# Altium schematic sheet USB UART.SchDoc("USB UART")
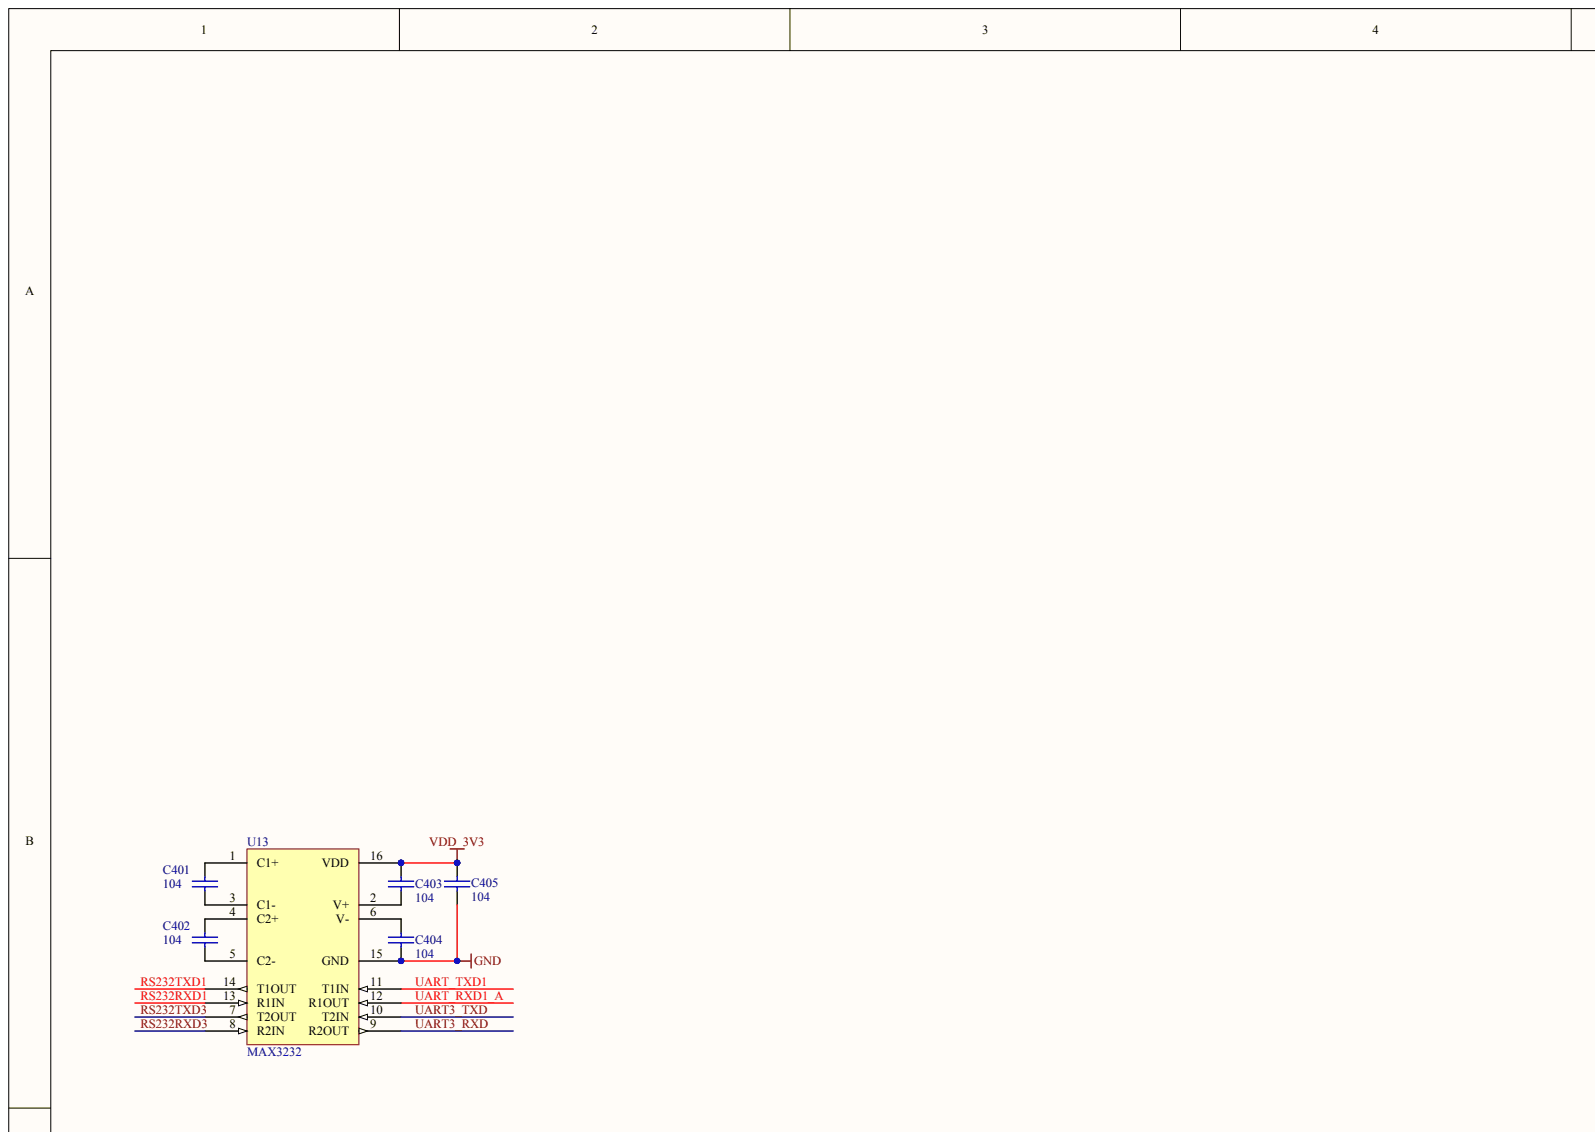
[diagram p0: USB UART.SchDoc, top left]
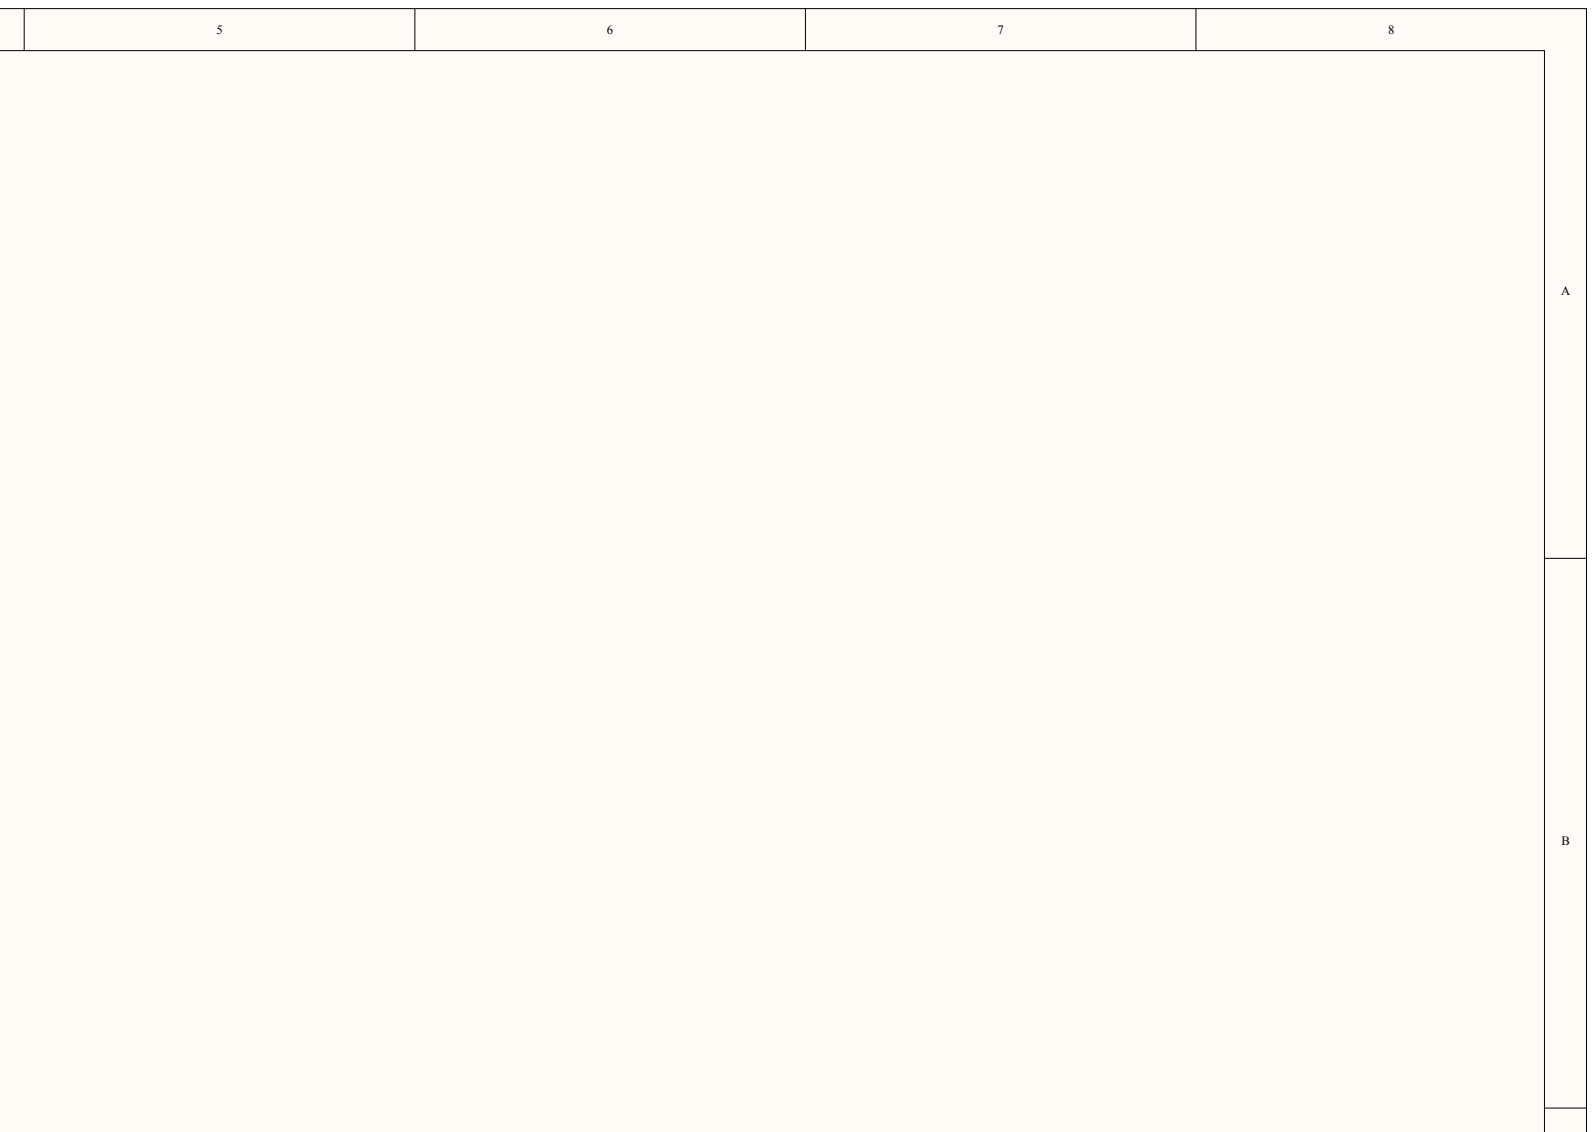
[diagram p1: USB UART.SchDoc, top right]
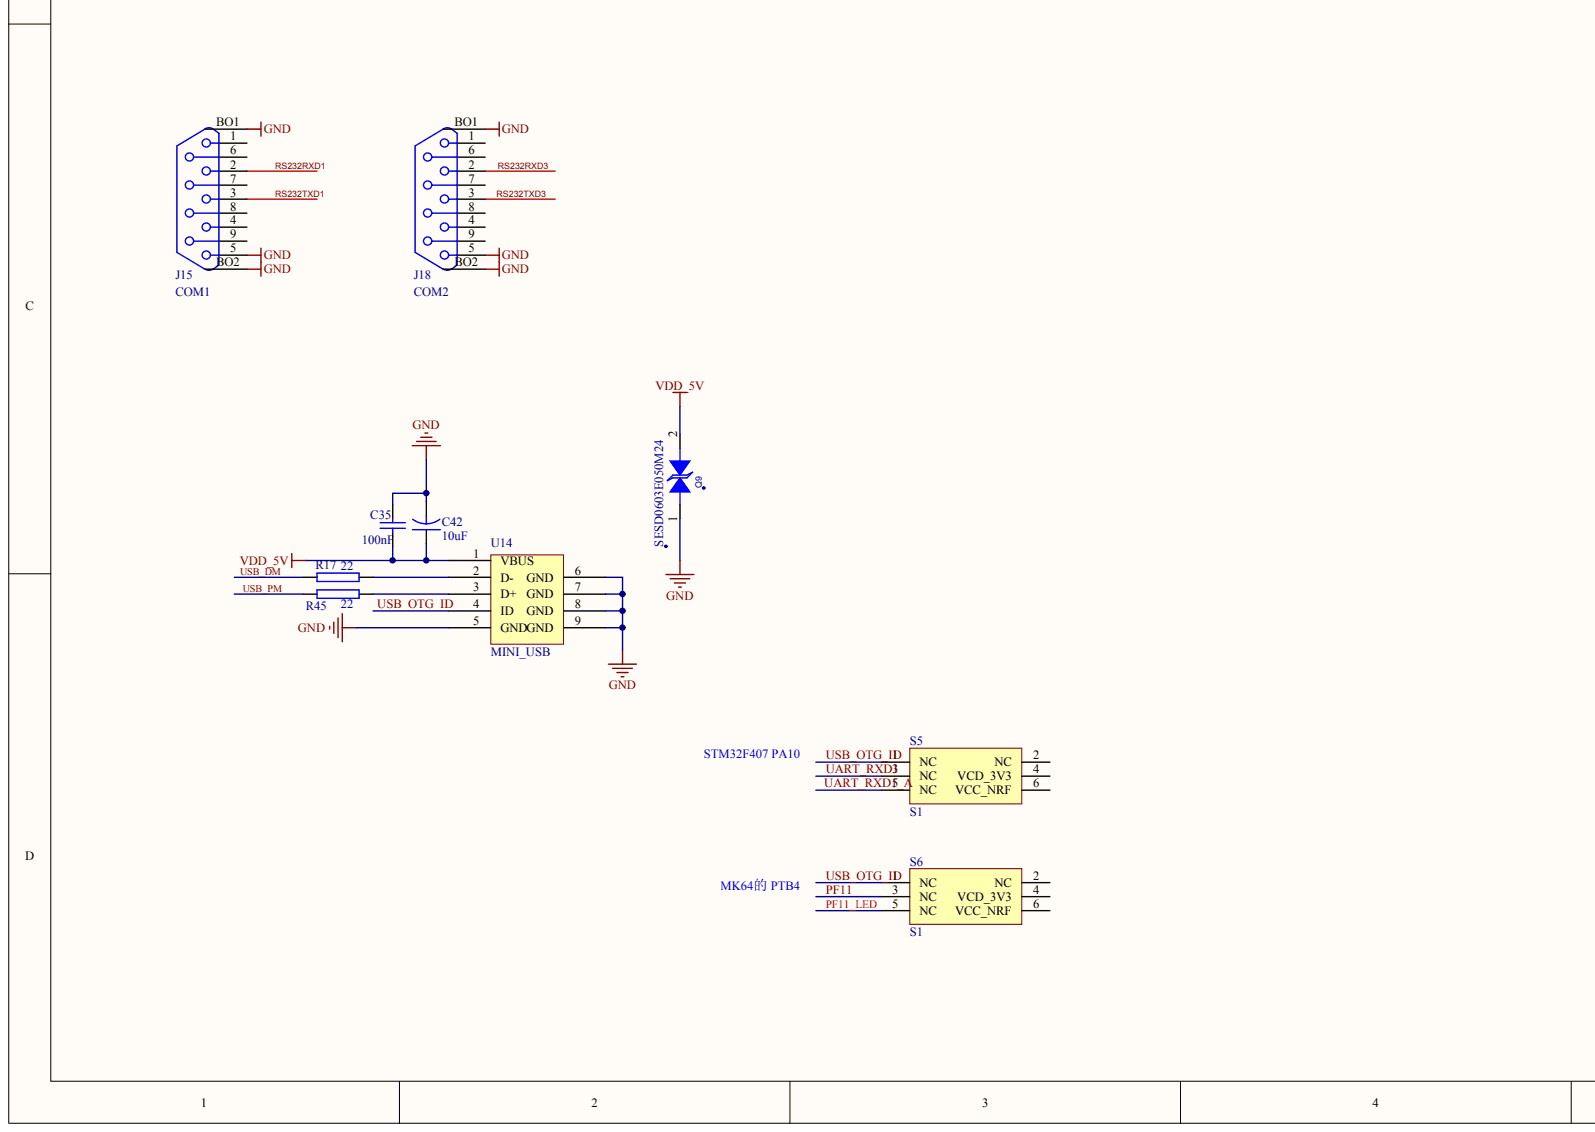
[diagram p2: USB UART.SchDoc, bottom left]
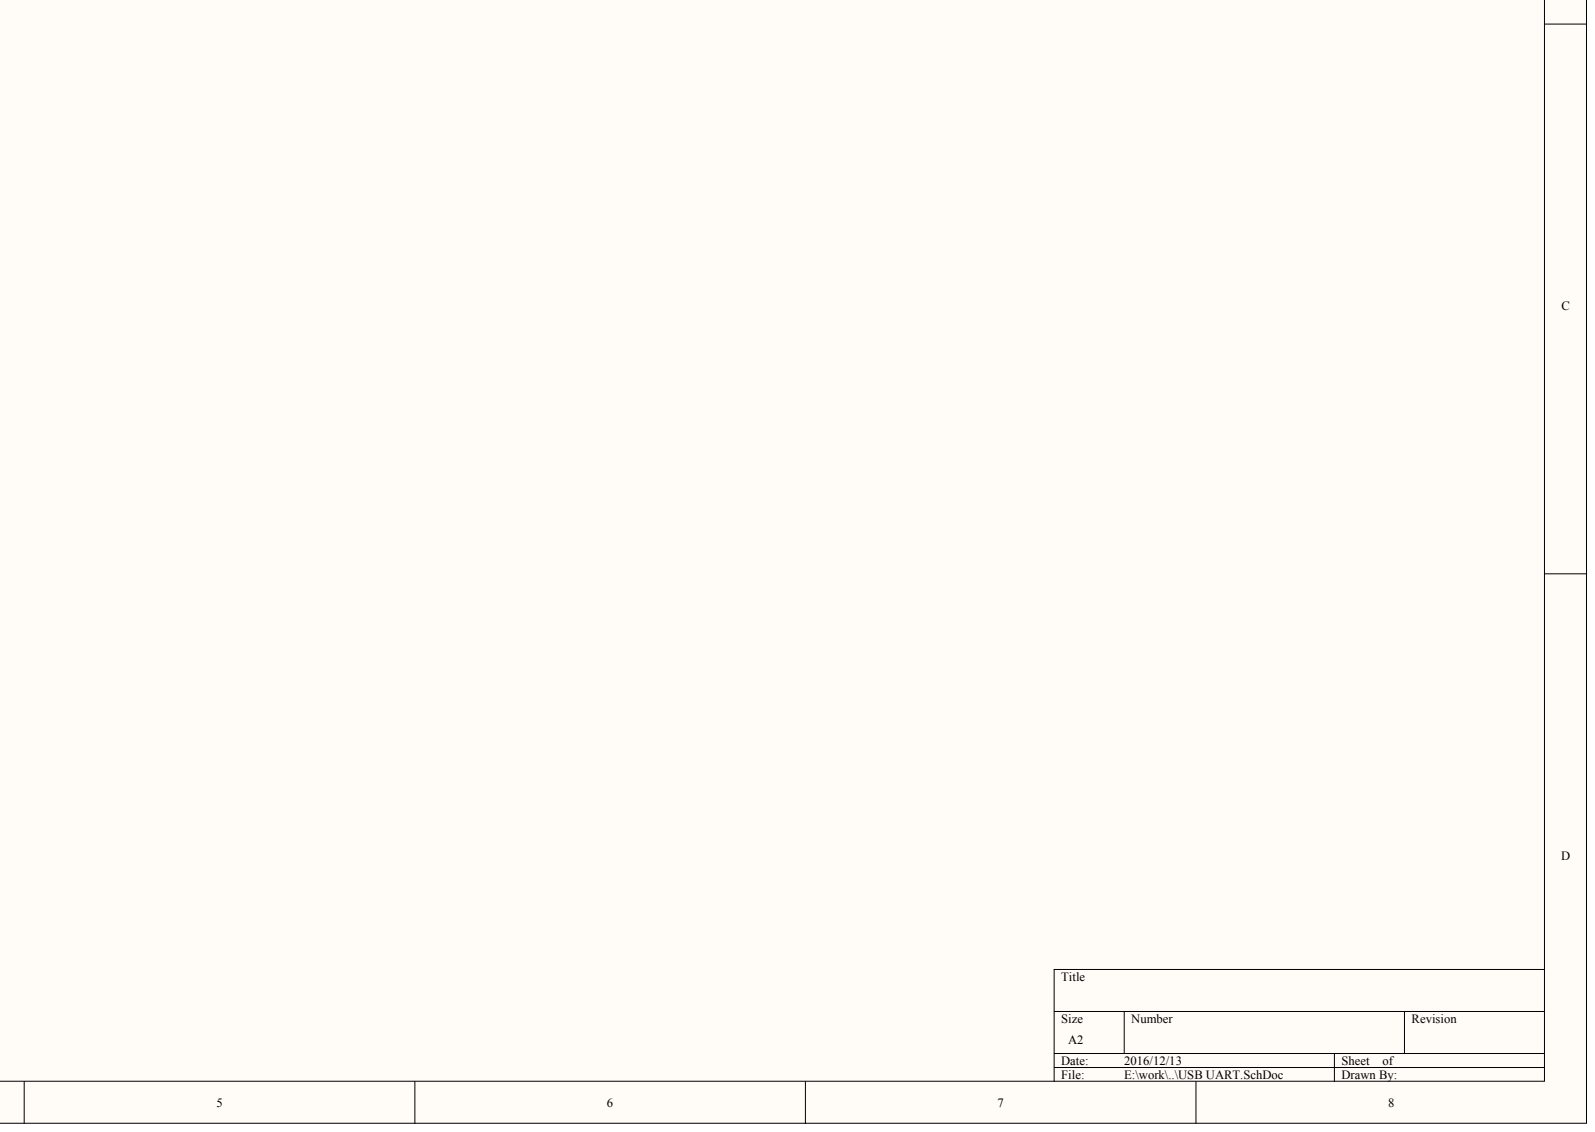
[diagram p3: USB UART.SchDoc, bottom right]

## Parts
C35  100nF
C42  10uF
C401  104
C402  104
C403  104
C404  104
C405  104
J15  COM1
J18  COM2
Q9  SESD0603E050M24
R17  22
R45  22
S5  S1
S6  S1
U13  MAX3232
U14  MINI_USB

## Nets
GND: C35.2 C42.2 C404.2 C405.2 J15.5 J15.BO1 J15.BO2 J18.5 J18.BO1 J18.BO2 Q9.1 U13.15(GND) U14.5(GND) U14.6(GND) U14.7(GND) U14.8(GND) U14.9(GND)
VDD_3V3: C403.1 C405.1 U13.16(VDD)
VDD_5V: C35.1 C42.1 Q9.2 U14.1(VBUS)
PF11: S6.3(NC)
PF11_LED: S6.5(NC)
RS232RXD1: J15.2 U13.13(R1IN)
RS232RXD3: J18.2 U13.8(R2IN)
RS232TXD1: J15.3 U13.14(T1OUT)
RS232TXD3: J18.3 U13.7(T2OUT)
UART3_RXD: U13.9(R2OUT)
UART3_TXD: U13.10(T2IN)
UART_RXD1: S5.3(NC)
UART_RXD1_A: S5.5(NC) U13.12(R1OUT)
UART_TXD1: U13.11(T1IN)
USB_DM: R17.1
USB_OTG_ID: S5.1(NC) S6.1(NC) U14.4(ID)
USB_PM: R45.1
NetC401_1: C401.1 U13.1(C1+)
NetC401_2: C401.2 U13.3(C1-)
NetC402_1: C402.1 U13.4(C2+)
NetC402_2: C402.2 U13.5(C2-)
NetC403_2: C403.2 U13.2(V+)
NetC404_1: C404.1 U13.6(V-)
NetR17_2: R17.2 U14.2(D-)
NetR45_2: R45.2 U14.3(D+)

Not connected: J15 1 4 6 7 8 9; J18 1 4 6 7 8 9; S5 2(NC) 4(VCD_3V3) 6(VCC_NRF); S6 2(NC) 4(VCD_3V3) 6(VCC_NRF)

## Library data of the parts
Q9 = (no symbol name): CLASS: IC; CAD_APPROVED: Yes; MFG_PN01: ESD9B3.3ST5G; MFG_NAME01: On Semiconductor; AGILE_PN: 480-77957; HEIGHT: 0.4MM; ROHS: Yes
U13 = (no symbol name): Text Field1: 90; Text Field2: 190
